# Altium schematic sheet sensor_sch.SchDoc
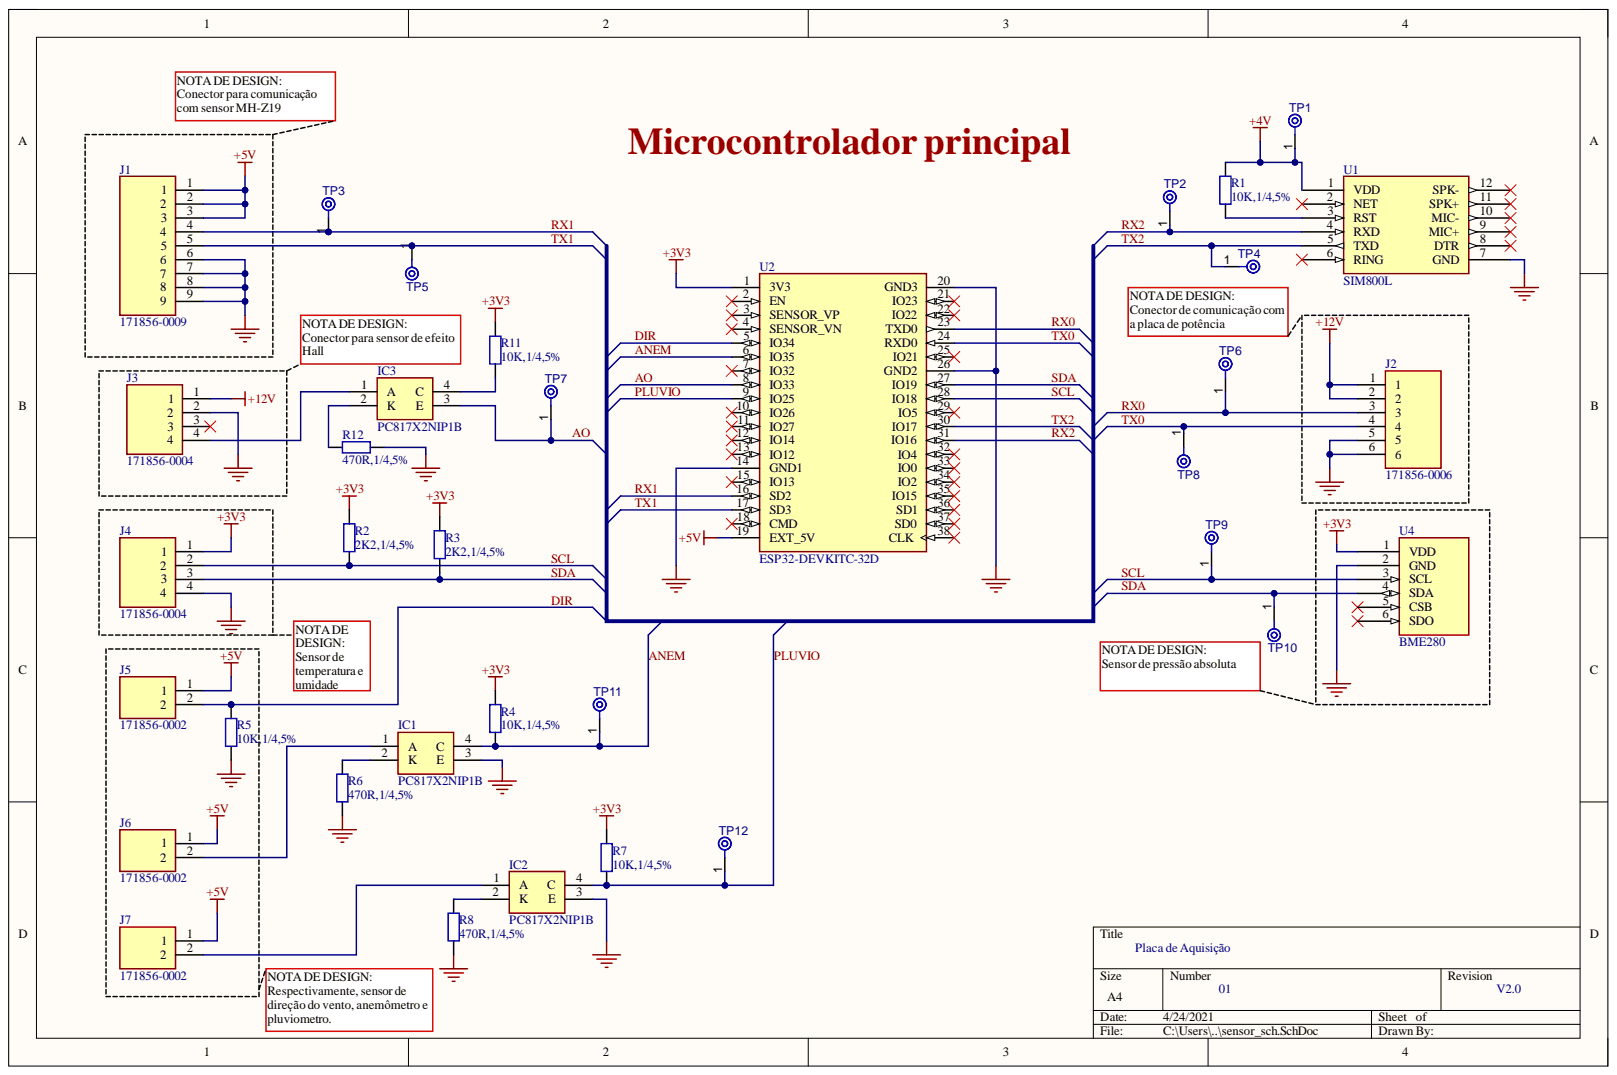
[diagram p0: sensor_sch.SchDoc]

## Parts
IC1  PC817X2NIP1B  [SOP254P960X420-4N]
IC2  PC817X2NIP1B  [SOP254P960X420-4N]
IC3  PC817X2NIP1B  [SOP254P960X420-4N]
J1  171856-0009  [SHDR9W99P0X254_1X9_2271X635X818P]
J2  171856-0006  [HDRV6W99P0X254_1X6_1509X635X1100P]
J3  171856-0004  [SHDR4W99P0X254_1X4_1255X635X1124P]
J4  171856-0004  [SHDR4W99P0X254_1X4_1255X635X1124P]
J5  171856-0002  [SHDR2W99P0X254_1X2_493X635X818P]
J6  171856-0002  [SHDR2W99P0X254_1X2_493X635X818P]
J7  171856-0002  [SHDR2W99P0X254_1X2_493X635X818P]
R1  value 10K,1/4,5%  [RESC3216X60N]  (hidden comment: Res2)
R2  value 2K2,1/4,5%  [RESC3216X60N]  (hidden comment: Res2)
R3  value 2K2,1/4,5%  [RESC3216X60N]  (hidden comment: Res2)
R4  value 10K,1/4,5%  [RESC3216X60N]  (hidden comment: Res2)
R5  value 10K,1/4,5%  [RESC3216X60N]  (hidden comment: Res2)
R6  value 470R,1/4,5%  [RESC3216X60N]  (hidden comment: Res2)
R7  value 10K,1/4,5%  [RESC3216X60N]  (hidden comment: Res2)
R8  value 470R,1/4,5%  [RESC3216X60N]  (hidden comment: Res2)
R11  value 10K,1/4,5%  [RESC3216X60N]  (hidden comment: Res2)
R12  value 470R,1/4,5%  [RESC3216X60N]  (hidden comment: Res2)
TP1  TPAD6R  [TP06R]  (value not drawn)  (no BOM)
TP2  TPAD6R  [TP06R]  (value not drawn)  (no BOM)
TP3  TPAD6R  [TP06R]  (value not drawn)  (no BOM)
TP4  TPAD6R  [TP06R]  (value not drawn)  (no BOM)
TP5  TPAD6R  [TP06R]  (value not drawn)  (no BOM)
TP6  TPAD6R  [TP06R]  (value not drawn)  (no BOM)
TP7  TPAD6R  [TP06R]  (value not drawn)  (no BOM)
TP8  TPAD6R  [TP06R]  (value not drawn)  (no BOM)
TP9  TPAD6R  [TP06R]  (value not drawn)  (no BOM)
TP10  TPAD6R  [TP06R]  (value not drawn)  (no BOM)
TP11  TPAD6R  [TP06R]  (value not drawn)  (no BOM)
TP12  TPAD6R  [TP06R]  (value not drawn)  (no BOM)
U1  SIM800L  [SIM800L]
U2  ESP32-DEVKITC-32D  [MODULE_ESP32-DEVKITC-32D]
U4  BME280  [BME280]

## Nets
+3V3: J4.1 R2.2 R3.2 R4.2 R7.2 R11.2 U2.1(3V3) U4.1(VDD)
+4V: R1.2 TP1.1 U1.1(VDD)
+5V: J1.1 J1.2 J1.3 J5.1 J6.1 J7.1 U2.19(EXT_5V)
+12V: J2.1 J2.2 J3.1
GND: IC1.3(E) IC2.3(E) J1.6 J1.7 J1.8 J1.9 J2.5 J2.6 J3.2 J4.4 R5.1 R6.1 R8.1 R12.1 U1.7(GND) U2.14(GND1) U2.20(GND3) U2.26(GND2) U4.2(GND)
ANEM: IC1.4(C) R4.1 TP11.1 U2.6(IO35)
AO: IC3.3(E) TP7.1 U2.8(IO33)
DIR: J5.2 R5.2 U2.5(IO34)
PLUVIO: IC2.4(C) R7.1 TP12.1 U2.9(IO25)
RX0: J2.3 TP6.1 U2.23(TXD0)
RX1: J1.4 TP3.1 U2.16(SD2)
RX2: TP2.1 U1.4(RXD) U2.31(IO16)
SCL: J4.2 R2.1 TP9.1 U2.28(IO18) U4.3(SCL)
SDA: J4.3 R3.1 TP10.1 U2.27(IO19) U4.4(SDA)
TX0: J2.4 TP8.1 U2.24(RXD0)
TX1: J1.5 TP5.1 U2.17(SD3)
TX2: TP4.1 U1.5(TXD) U2.30(IO17)
NetIC1_1: IC1.1(A) J6.2
NetIC1_2: IC1.2(K) R6.2
NetIC2_1: IC2.1(A) J7.2
NetIC2_2: IC2.2(K) R8.2
NetIC3_1: IC3.1(A) J3.4
NetIC3_2: IC3.2(K) R12.2
NetIC3_4: IC3.4(C) R11.1
NetR1_1: R1.1 U1.3(RST)

Not connected: J3 3; U1 2(NET) 6(RING) 8(DTR) 9(MIC+) 10(MIC-) 11(SPK+) 12(SPK-); U2 2(EN) 3(SENSOR_VP) 4(SENSOR_VN) 7(IO32) 10(IO26) 11(IO27) 12(IO14) 13(IO12) 15(IO13) 18(CMD) 21(IO23) 22(IO22) 25(IO21) 29(IO5) 32(IO4) 33(IO0) 34(IO2) 35(IO15) 36(SD1) 37(SD0) 38(CLK); U4 5(CSB) 6(SDO)

## Library data of the parts
IC1-IC3 = PC817X2NIP1B: Transistor Output Optocouplers Photocoupler; Manufacturer: Sharp; Manufacturer_Name: Sharp Microelectronics; Arrow Part Number: PC817X2NIP1B; Arrow Price/Stock: https://www.arrow.com/en/products/pc817x2nip1b/sharp; Category: Optocouplers; Component Kind: Standard; Datasheet Link: https://global.sharp/products/device/lineup/data/pdf/datasheet/PC817XxNSZ1B_e.pdf; Height: 4.2mm; Manufacturer Part Number: PC817X2NIP1B; Manufacturer_Part_Number: PC817X2NIP1B; Mouser Part Number: 852-PC817X2NIP1B; Mouser Price/Stock: https://www.mouser.co.uk/ProductDetail/Sharp-Microelectronics/PC817X2NIP1B?qs=rrS6PyfT74d%252BYyTnGmD7xQ%3D%3D; Pricing 1: 1=0.51000, 10=0.33400, 50=0.33400, 100=0.21900, 1000=0.17300, 10000=0.14100 (USD); RoHS: Yes; RoHS Compliant: Yes; Stock 1: 7; Supplier 1: Mouser; Supplier Part Number 1: 852-PC817X2NIP1B
J1 = 171856-0009: Headers & Wire Housings KK Hdr Vrt 9 Ckt 2.54mm Pitch Sn; Manufacturer: Molex; Manufacturer Part Number: 1718560009; Connector Type: Male Pin; Contact Post Length: 2.34mm; Current Rating: 4A; Glow Wire Compliant: Compliant; Number of Circuits: 9; Voltage Rating: 250V; Category: Headers and Wire Housings; Color: Black; Component Kind: Standard; ComponentLink1Description: https://datasheet.ciiva.com/24242/1718560009-pcb-headers-262932-24242129.pdf?src-supplier=Mouser; ComponentLink1URL: https://datasheet.ciiva.com/24242/1718560009-pcb-headers-262932-24242129.pdf?src-supplier=Mouser; Contact Gender: Male; Contact Material: Brass; Contact Mating Length: 0.293inch; Contact Plating: Tin; Datasheet Link: https://www.molex.com/pdm_docs/sd/1718560009_sd.pdf; Fastening Type: Friction; Flammability Rating: UL94 V-2; Halogen Free: Low Halogen; Height: 8.18mm; Housing Material: Nylon; Manufacturer_Name: Molex; Manufacturer_Part_Number: 171856-0009; Max Operating Temperature: 105°C; Min Operating Temperature: -40°C; Mount: Through Hole; Mouser Part Number: 538-171856-0009; Mouser Price/Stock: https://www.mouser.com/Search/Refine.aspx?Keyword=538-171856-0009; Number of Positions: 9; Number of Rows: 1; Orientation: Vertical; Package Quantity: 1000; Packaging: Bulk; Pitch: 2.54mm; Pricing 1: 1=0.83000, 10=0.68600, 100=0.57150, 1000=0.45717, 5000=0.40002, 10000=0.38002 (USD); RoHS: Yes; RoHS Compliant: Yes; Stock 1: 1734; Supplier 1: Digi-Key; Supplier Part Number 1: WM10186-ND; Termination: Solder; Weight: 0.036367oz
J2 = 171856-0006: Conn Wire to Board HDR 6 POS 2.54mm Solder ST Thru-Hole KK® Bag; Manufacturer: Molex; Connector Type: Male Pin; Contact Post Length: 2.34mm; Current Rating: 4A; Gender: Male; Glow Wire Compliant: Compliant; Number of Circuits: 6; Voltage Rating: 250V; Category: Headers and Wire Housings; Color: Black; Component Kind: Standard; ComponentLink1Description: https://datasheet.ciiva.com/24135/1718560006-pcb-headers-295618-24135976.pdf?src-supplier=Mouser; ComponentLink1URL: https://datasheet.ciiva.com/24135/1718560006-pcb-headers-295618-24135976.pdf?src-supplier=Mouser; ComponentLink2Description: https://datasheet.ciiva.com/30069/162461718560006-pcb-headers-30069863.pdf?src-supplier=Onlinecomponents.com; ComponentLink2URL: https://datasheet.ciiva.com/30069/162461718560006-pcb-headers-30069863.pdf?src-supplier=Onlinecomponents.com; ComponentLink3Description: https://datasheet.ciiva.com/28500/1769843-28500365.pdf?src-supplier=Element14; ComponentLink3URL: https://datasheet.ciiva.com/28500/1769843-28500365.pdf?src-supplier=Element14; ComponentLink4Description: https://datasheet.ciiva.com/30492/0900766b8129e59b-30492739.pdf?src-supplier=RSComponents; ComponentLink4URL: https://datasheet.ciiva.com/30492/0900766b8129e59b-30492739.pdf?src-supplier=RSComponents; ComponentLink5Description: https://datasheet.ciiva.com/29948/1718560002-sd-29948527.pdf?src-supplier=Avnet; ComponentLink5URL: https://datasheet.ciiva.com/29948/1718560002-sd-29948527.pdf?src-supplier=Avnet; ComponentLink6Description: https://datasheet.ciiva.com/30514/70314281-30514598.pdf?src-supplier=Allied+Electronics; ComponentLink6URL: https://datasheet.ciiva.com/30514/70314281-30514598.pdf?src-supplier=Allied+Electronics; Contact Gender: Male; Contact Material: Brass,Tin; Contact Mating Length: 0.293inch; Contact Plating: Tin,Matte; Datasheet Link: https://www.molex.com/pdm_docs/sd/1718560006_sd.pdf; Depth: 6.35mm; Fastening Type: Friction; Flammability Rating: UL94 V-2; Halogen Free: Low Halogen; Height: 11mm; Housing Color: Black; Housing Material: Nylon; Length: 15.09mm; Manufacturer Part Number: 171856-0006; Manufacturer_Name: Molex; Manufacturer_Part_Number: 171856-0006; Max Operating Temperature: 105°C; Min Operating Temperature: -40°C; Mount: Through Hole; Mouser Part Number: 538-171856-0006; Mouser Price/Stock: https://www.mouser.co.uk/ProductDetail/Molex/171856-0006?qs=s7UCm7gO1bZ%2FF4kPA9T7bA%3D%3D; Number of Contacts: 6; Number of Positions: 6; Number of Rows: 1; Orientation: Vertical; Package Quantity: 2000; Packaging: Bulk; Pitch: 2.54mm; Pricing 1: 1=0.52000, 10=0.44100, 50=0.44100, 100=0.34400, 1000=0.27000, 10000=0.22000 (USD); Radiation Hardening: No; REACH SVHC: Unknown; RoHS: Yes; RoHS Compliant: Yes; Stock 1: 7801; Supplier 1: Mouser; Supplier Part Number 1: 538-171856-0006; Termination: Solder; Weight: 0.023916oz
J3 J4 = 171856-0004: KK RPC 100 HDR FRLK VERT 04 CKT; Manufacturer: Molex; Manufacturer Part Number: 1718560004; Connector Type: Male Pin; Contact Post Length: 2.34mm; Current Rating: 4A; Gender: Male; Glow Wire Compliant: Compliant; Number of Circuits: 4; Pitch: 2.54mm; Voltage Rating: 250V; Category: Headers and Wire Housings; Color: Black; Component Kind: Standard; ComponentLink1Description: https://datasheet.ciiva.com/24135/1718560004-pcb-headers-295616-24135986.pdf?src-supplier=Mouser; ComponentLink1URL: https://datasheet.ciiva.com/24135/1718560004-pcb-headers-295616-24135986.pdf?src-supplier=Mouser; ComponentLink2Description: https://datasheet.ciiva.com/30102/162471718560004-pcb-headers-30102326.pdf?src-supplier=Onlinecomponents.com; ComponentLink2URL: https://datasheet.ciiva.com/30102/162471718560004-pcb-headers-30102326.pdf?src-supplier=Onlinecomponents.com; ComponentLink3Description: https://datasheet.ciiva.com/30492/0900766b8129e622-30492729.pdf?src-supplier=RSComponents; ComponentLink3URL: https://datasheet.ciiva.com/30492/0900766b8129e622-30492729.pdf?src-supplier=RSComponents; ComponentLink4Description: https://datasheet.ciiva.com/28500/1769844-28500352.pdf?src-supplier=Element14; ComponentLink4URL: https://datasheet.ciiva.com/28500/1769844-28500352.pdf?src-supplier=Element14; ComponentLink5Description: https://datasheet.ciiva.com/29948/1718560002-sd-29948535.pdf?src-supplier=Avnet; ComponentLink5URL: https://datasheet.ciiva.com/29948/1718560002-sd-29948535.pdf?src-supplier=Avnet; ComponentLink6Description: https://datasheet.ciiva.com/29152/1718560002-sd-29152029.pdf?src-supplier=Digi-Key; ComponentLink6URL: https://datasheet.ciiva.com/29152/1718560002-sd-29152029.pdf?src-supplier=Digi-Key; ComponentLink7Description: https://datasheet.ciiva.com/30935/70314280-30935659.pdf?src-supplier=Allied+Electronics; ComponentLink7URL: https://datasheet.ciiva.com/30935/70314280-30935659.pdf?src-supplier=Allied+Electronics; Contact Gender: Male; Contact Material: Brass,Tin; Contact Mating Length: 0.293inch; Contact Plating: Tin,Matte; Depth: 6.35mm; Fastening Type: Friction; Flammability Rating: UL94 V-2; Halogen Free: Low Halogen; Housing Color: Black; Housing Material: Nylon; Length: 10.1mm; Library Name: sensor_lib.SchLib; Max Operating Temperature: 105°C; Min Operating Temperature: -40°C; Mount: Through Hole; Number of Contacts: 4; Number of Positions: 4; Number of Rows: 1; Orientation: Vertical; Package Quantity: 4000; Packaging: Bulk; Pricing 1: 1=0.40000, 10=0.32400, 50=0.32400, 100=0.23800, 1000=0.20000, 10000=0.15600 (USD); Pricing 2: 1=0.40000, 10=0.32400, 50=0.32400, 100=0.23800, 1000=0.20000, 10000=0.15600 (USD); Radiation Hardening: No; REACH SVHC: Unknown; RoHS: Yes; RoHS Compliant: Yes; Stock 1: 33104; Stock 2: 33061; Supplier 1: Mouser; Supplier 2: Mouser; Supplier Part Number 2: 538-171856-0004; Termination: Solder; Weight: 0.015838oz
J5-J7 = 171856-0002: Conn Wire to Board HDR 2 POS 2.54mm Solder ST Thru-Hole KK® Bag; Manufacturer: Molex; Connector Type: Male Pin; Contact Post Length: 2.34mm; Current Rating: 4A; Gender: Male; Glow Wire Compliant: Compliant; Number of Circuits: 2; Voltage Rating: 250V; Arrow Part Number: 171856-0002; Arrow Price/Stock: https://www.arrow.com/en/products/171856-0002/molex; Category: Headers and Wire Housings; Color: Black; Component Kind: Standard; ComponentLink1Description: https://datasheet.ciiva.com/24135/1718560002-pcb-headers-262931-24135970.pdf?src-supplier=Mouser; ComponentLink1URL: https://datasheet.ciiva.com/24135/1718560002-pcb-headers-262931-24135970.pdf?src-supplier=Mouser; ComponentLink2Description: https://datasheet.ciiva.com/30239/162441718560002-pcb-headers-30239327.pdf?src-supplier=Onlinecomponents.com; ComponentLink2URL: https://datasheet.ciiva.com/30239/162441718560002-pcb-headers-30239327.pdf?src-supplier=Onlinecomponents.com; ComponentLink3Description: https://datasheet.ciiva.com/28500/1769839-28500337.pdf?src-supplier=Element14; ComponentLink3URL: https://datasheet.ciiva.com/28500/1769839-28500337.pdf?src-supplier=Element14; ComponentLink4Description: https://datasheet.ciiva.com/30492/0900766b8129e621-30492725.pdf?src-supplier=RSComponents; ComponentLink4URL: https://datasheet.ciiva.com/30492/0900766b8129e621-30492725.pdf?src-supplier=RSComponents; ComponentLink5Description: https://datasheet.ciiva.com/29152/1718560002-sd-29152023.pdf?src-supplier=Digi-Key; ComponentLink5URL: https://datasheet.ciiva.com/29152/1718560002-sd-29152023.pdf?src-supplier=Digi-Key; Contact Gender: Male; Contact Material: Brass,Tin; Contact Mating Length: 0.293inch; Contact Plating: Tin,Matte; Datasheet Link: https://www.digikey.com/product-detail/en/molex/1718560002/WM10153-ND/4423109; Depth: 6.35mm; Fastening Type: Friction; Flammability Rating: UL94 V-2; Halogen Free: Low Halogen; Height: 8.18mm; Housing Color: Black; Housing Material: Nylon; Length: 4.93mm; Library Name: sensor_lib.SchLib; Manufacturer Part Number: 171856-0002; Manufacturer_Name: Molex; Manufacturer_Part_Number: 171856-0002; Max Operating Temperature: 105°C; Min Operating Temperature: -40°C; Mount: Through Hole; Mouser Part Number: 538-171856-0002; Mouser Price/Stock: https://www.mouser.co.uk/ProductDetail/Molex/171856-0002?qs=s7UCm7gO1bYKeEMrirBzyw%3D%3D; Number of Contacts: 2; Number of Positions: 2; Number of Rows: 1; Orientation: Vertical; Package Quantity: 6000; Packaging: Bulk; Pitch: 2.54mm; Pricing 1: 1=0.27000, 10=0.22200, 50=0.22200, 100=0.16300, 1000=0.13000, 10000=0.10000 (USD); Radiation Hardening: No; REACH SVHC: Unknown; RoHS: Yes; Stock 1: 4493; Supplier 1: Mouser; Supplier Part Number 1: 538-171856-0002; Termination: Solder; Weight: 0.007549oz
R1 R4 R5 R7 R11 = Res2: VISHAY         CRCW120610K0JNEA             RES, THICK FILM, 10K, 5%, 0.25W, 1206, REEL; Manufacturer: Vishay Dale; Max Power Dissipation: 250mW; Resistance: 10kR; Temperature Coefficient: 200ppm/°C; Voltage Rating: 200V; Case Code (Imperial): 1206; Case Code (Metric): 3216; Case/Package: 1206; Category: Chip SMD Resistors; Component Kind: Standard; ComponentLink1Description: https://datasheet.ciiva.com/5546/dcrcwe3-109170-5546729.pdf?src-supplier=Mouser; ComponentLink1URL: https://datasheet.ciiva.com/5546/dcrcwe3-109170-5546729.pdf?src-supplier=Mouser; ComponentLink2Description: https://datasheet.ciiva.com/9050/dcrcwe3-9050936.pdf?src-supplier=Avnet; ComponentLink2URL: https://datasheet.ciiva.com/9050/dcrcwe3-9050936.pdf?src-supplier=Avnet; ComponentLink3Description: https://datasheet.ciiva.com/9050/dcrcwe3-9050939.pdf?src-supplier=Avnet; ComponentLink3URL: https://datasheet.ciiva.com/9050/dcrcwe3-9050939.pdf?src-supplier=Avnet; ComponentLink4Description: https://datasheet.ciiva.com/17190/dcrcwe3-17190266.pdf?src-supplier=Avnet+Europe; ComponentLink4URL: https://datasheet.ciiva.com/17190/dcrcwe3-17190266.pdf?src-supplier=Avnet+Europe; ComponentLink5Description: https://datasheet.ciiva.com/16389/dcrcwe3-16389593.pdf?src-supplier=Future+Electronics; ComponentLink5URL: https://datasheet.ciiva.com/16389/dcrcwe3-16389593.pdf?src-supplier=Future+Electronics; ComponentLink6Description: https://datasheet.ciiva.com/7800/dcrcwe3-7800542.pdf?src-supplier=Element14; ComponentLink6URL: https://datasheet.ciiva.com/7800/dcrcwe3-7800542.pdf?src-supplier=Element14; ComponentLink7Description: https://datasheet.ciiva.com/16724/70201119-16724109.pdf?src-supplier=Allied+Electronics; ComponentLink7URL: https://datasheet.ciiva.com/16724/70201119-16724109.pdf?src-supplier=Allied+Electronics; Construction: Rectangular; Contact Plating: Tin; Depth: 1.6mm; Features: Automotive AEC-Q200; Height: 0.55mm; LatestRevisionDate: 17-Jul-2002; LatestRevisionNote: Re-released for DXP Platform.; Lead Free: Lead Free; Length: 3.2mm; Library Name: Miscellaneous Devices.IntLib; Manufacturer Part Number: CRCW120610K0JNEA; Max Operating Temperature: 155°C; Military Standard: Not; Min Operating Temperature: -55°C; Number of Pins: 2; Number of Terminations: 2; Package Quantity: 5000; PackageDescription: Axial Device, Thru-Hole; 2 Leads; 0.4 in Pin Spacing; PackageReference: AXIAL-0.4; Packaging: Tape and Reel; Power Rating: 250mW; Pricing 1: 1=0.10000, 10=0.06500, 50=0.06500, 100=0.03000, 1000=0.01200, 10000=0.01000 (USD); Published: 8-Jun-2000; Radiation Hardening: No; REACH SVHC: Unknown; Resistance Tolerance: 5%; Resistor Type: Thick Film; RoHS: Yes; Series: CRCW; Signal Integrity: Res; Simulation: RESISTOR; Size Code: 1206; Stock 1: 96532; Supplier 1: Mouser; Supplier Part Number 1: 71-CRCW1206J-10K-E3; Termination: SMD/SMT; Tolerance: 5%; Voltage Rating (DC): 200V; Weight: 0.000353oz; Width: 1.6mm; Publisher: Altium Limited
R2 R3 = Res2: VISHAY   CRCW12062K20JNEA   RES, THICK FILM, 2K2, 5%, 0.25W, 1206, REEL; Manufacturer: Vishay Dale; Max Power Dissipation: 250mW; Resistance: 2.2kR; Temperature Coefficient: 200ppm/°C; Voltage Rating: 200V; Case Code (Imperial): 1206; Case Code (Metric): 3216; Case/Package: 1206; Category: Chip SMD Resistors; Component Kind: Standard; ComponentLink1Description: https://datasheet.ciiva.com/9091/dcrcwe3-9091080.pdf?src-supplier=Avnet; ComponentLink1URL: https://datasheet.ciiva.com/9091/dcrcwe3-9091080.pdf?src-supplier=Avnet; ComponentLink2Description: https://datasheet.ciiva.com/5543/dcrcwe3-109170-5543996.pdf?src-supplier=Mouser; ComponentLink2URL: https://datasheet.ciiva.com/5543/dcrcwe3-109170-5543996.pdf?src-supplier=Mouser; ComponentLink3Description: https://datasheet.ciiva.com/17201/dcrcwe3-17201852.pdf?src-supplier=Avnet+Europe; ComponentLink3URL: https://datasheet.ciiva.com/17201/dcrcwe3-17201852.pdf?src-supplier=Avnet+Europe; ComponentLink4Description: https://datasheet.ciiva.com/46448/1807946-46448335.pdf?src-supplier=Element14; ComponentLink4URL: https://datasheet.ciiva.com/46448/1807946-46448335.pdf?src-supplier=Element14; ComponentLink5Description: https://datasheet.ciiva.com/16755/70201119-16755609.pdf?src-supplier=Allied+Electronics; ComponentLink5URL: https://datasheet.ciiva.com/16755/70201119-16755609.pdf?src-supplier=Allied+Electronics; Construction: Rectangular; Contact Plating: Tin; Depth: 1.6mm; Features: Automotive AEC-Q200; Height: 0.55mm; LatestRevisionDate: 17-Jul-2002; LatestRevisionNote: Re-released for DXP Platform.; Lead Free: Lead Free; Length: 3.2mm; Library Name: Miscellaneous Devices.IntLib; Manufacturer Part Number: CRCW12062K20JNEA; Max Operating Temperature: 155°C; Military Standard: Not; Min Operating Temperature: -55°C; Mount: Surface Mount; Number of Terminations: 2; Package Quantity: 5000; PackageDescription: Axial Device, Thru-Hole; 2 Leads; 0.4 in Pin Spacing; PackageReference: AXIAL-0.4; Packaging: Tape and Reel; Power Rating: 250mW; Pricing 1: 1=0.10000, 10=0.05900, 50=0.05900, 100=0.02700, 1000=0.01100, 10000=0.01000 (USD); Published: 8-Jun-2000; Radiation Hardening: No; REACH SVHC: Unknown; Resistance Tolerance: 5%; Resistor Type: Thick Film; RoHS: Yes; RoHS Compliant: Yes; Series: CRCW; Signal Integrity: Res; Simulation: RESISTOR; Size Code: 1206; Stock 1: 46; Supplier 1: Mouser; Supplier Part Number 1: 71-CRCW1206J-2.2K-E3; Technology: THICK FILM; Termination: Solder; Tolerance: 5%; Voltage Rating (DC): 200V; Weight: 0.000353oz; Width: 1.6mm; Publisher: Altium Limited
R6 R8 R12 = Res2: Thick Film Resistors 1/4watt 470ohms 5%; Manufacturer: Vishay; Manufacturer Part Number: CRCW1206470RJNEAIF; Max Power Dissipation: 250mW; Resistance: 470R; Temperature Coefficient: 200ppm/°C; Voltage Rating: 200V; Case Code (Imperial): 1206; Case Code (Metric): 3216; Case/Package: 1206; Category: Chip SMD Resistors; Component Kind: Standard; ComponentLink1Description: https://datasheet.ciiva.com/7777/424674-7777482.pdf?src-supplier=Element14; ComponentLink1URL: https://datasheet.ciiva.com/7777/424674-7777482.pdf?src-supplier=Element14; ComponentLink2Description: https://datasheet.ciiva.com/7777/424674-7777483.pdf?src-supplier=Element14; ComponentLink2URL: https://datasheet.ciiva.com/7777/424674-7777483.pdf?src-supplier=Element14; Construction: Rectangular; Depth: 1.6mm; Features: Automotive AEC-Q200; Height: 0.55mm; LatestRevisionDate: 17-Jul-2002; LatestRevisionNote: Re-released for DXP Platform.; Lead Free: Lead Free; Length: 3.2mm; Library Name: Miscellaneous Devices.IntLib; Max Operating Temperature: 155°C; Military Standard: Not; Min Operating Temperature: -55°C; Number of Terminations: 2; Package Quantity: 5000; PackageDescription: Axial Device, Thru-Hole; 2 Leads; 0.4 in Pin Spacing; PackageReference: AXIAL-0.4; Packaging: Tape and Reel; Power Rating: 250mW; Pricing 1: 1=0.56000, 10=0.40300, 50=0.40300, 100=0.21000, 1000=0.11300, 10000=0.09500 (USD); Published: 8-Jun-2000; REACH SVHC: Unknown; Resistance Tolerance: 5%; Resistor Type: Thick Film; RoHS: Yes; RoHS Compliant: Yes; Series: CRCW-IF; Signal Integrity: Res; Simulation: RESISTOR; Stock 1: 6264; Supplier 1: Mouser; Supplier Part Number 1: 71-CRCW1206470RJNAIF; Technology: THICK FILM; Termination: SMD/SMT; Tolerance: 5%; Voltage Rating (DC): 200V; Weight: 0.000353oz; Width: 1.6mm; Publisher: Altium Limited
TP1-TP12 = CMP-00028-00002-2: 0.6mm round; Library Name: PI2; Pins: 1
U2 = ESP32-DEVKITC-32D: Espressif Systems ESP32-DevKitC Microcontroller; Frequency: 2.5GHz; Interface: USB; Operating Supply Voltage: 5V; Availability: Warning; Check_prices: https://www.snapeda.com/parts/ESP32-DEVKITC-32D/Espressif%20Systems/view-part/2777395/?ref=eda; Component Kind: Standard; ComponentLink1Description: https://datasheet.ciiva.com/70828/esp32-devkit-70828764.pdf?src-supplier=TME; ComponentLink1URL: https://datasheet.ciiva.com/70828/esp32-devkit-70828764.pdf?src-supplier=TME; Library Name: sensor_lib.SchLib; Manufacturer: Espressif Systems; Manufacturer Part Number: ESP32-DEVKITC-32D; MF: Espressif Systems; MP: ESP32-DEVKITC-32D; Package: None; Package Quantity: 1; Price: None; Pricing 1: 1=10.00000, 10=10.00000, 50=10.00000, 100=10.00000, 1000=10.00000, 10000=10.00000 (USD); Purchase-URL: https://pricing.snapeda.com/search/part/ESP32-DEVKITC-32D/?ref=eda; RoHS: No; SnapEDA_Link: https://www.snapeda.com/parts/ESP32-DEVKITC-32D/Espressif%20Systems/view-part/2777395/?ref=snap; Stock 1: 1545; Supplier 1: Mouser; Supplier Part Number 1: 356-ESP32-DEVKITC32D
U4 = BME280: Digital Pressure Sensor; Component Kind: Standard; Library Name: sensor_lib.SchLib
U1 = SIM800L: Component Kind: Standard; Library Name: sensor_lib.SchLib
